# Altium schematic sheet KillSwitch_MotorOut.SchDoc
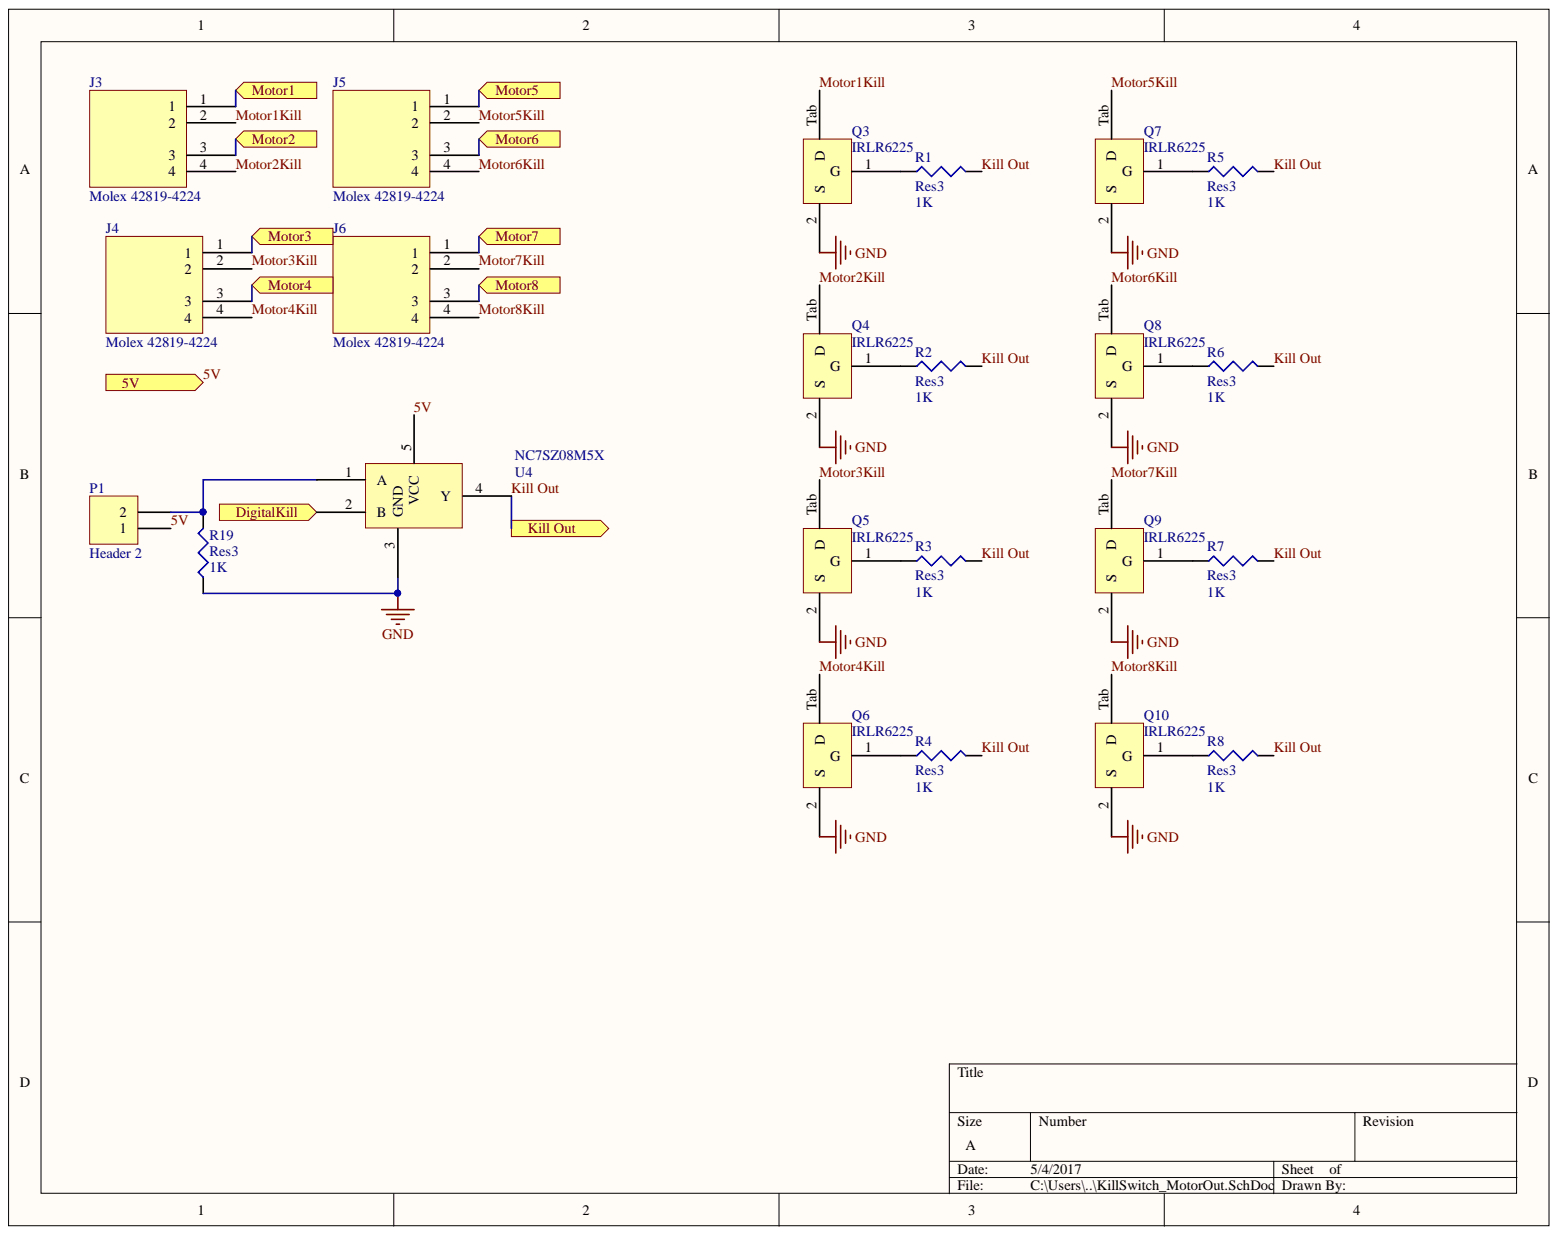
[diagram p0: KillSwitch_MotorOut.SchDoc]

## Parts
J3  Molex 42819-4224  [MOLEX_42819-4224]
J4  Molex 42819-4224  [MOLEX_42819-4224]
J5  Molex 42819-4224  [MOLEX_42819-4224]
J6  Molex 42819-4224  [MOLEX_42819-4224]
NC7SZ08M5X  U4  [NC7SZ08M5X]
P1  Header 2  [HDR1X2]
Q3  IRLR6225  [IRLR6225]
Q4  IRLR6225  [IRLR6225]
Q5  IRLR6225  [IRLR6225]
Q6  IRLR6225  [IRLR6225]
Q7  IRLR6225  [IRLR6225]
Q8  IRLR6225  [IRLR6225]
Q9  IRLR6225  [IRLR6225]
Q10  IRLR6225  [IRLR6225]
R1  Res3  value 1K  [J1-0603]
R2  Res3  value 1K  [J1-0603]
R3  Res3  value 1K  [J1-0603]
R4  Res3  value 1K  [J1-0603]
R5  Res3  value 1K  [J1-0603]
R6  Res3  value 1K  [J1-0603]
R7  Res3  value 1K  [J1-0603]
R8  Res3  value 1K  [J1-0603]
R19  Res3  value 1K  [J1-0603]

## Ports
5V, DIGITALKILL, KILL OUT, MOTOR1, MOTOR2, MOTOR3, MOTOR4, MOTOR5, MOTOR6, MOTOR7, MOTOR8

## Nets
GND: NC7SZ08M5X.3(GND) Q3.2 Q4.2 Q5.2 Q6.2 Q7.2 Q8.2 Q9.2 Q10.2 R19.1
5V: NC7SZ08M5X.5(VCC) P1.1 ; port 5V
KILL OUT: NC7SZ08M5X.4(Y) R1.2 R2.2 R3.2 R4.2 R5.2 R6.2 R7.2 R8.2 ; port KILL OUT
MOTOR1KILL: J3.2 Q3.Tab
MOTOR2KILL: J3.4 Q4.Tab
MOTOR3KILL: J4.2 Q5.Tab
MOTOR4KILL: J4.4 Q6.Tab
MOTOR5KILL: J5.2 Q7.Tab
MOTOR6KILL: J5.4 Q8.Tab
MOTOR7KILL: J6.2 Q9.Tab
MOTOR8KILL: J6.4 Q10.Tab
NetJ3_1: J3.1 ; port MOTOR1
NetJ3_3: J3.3 ; port MOTOR2
NetJ4_1: J4.1 ; port MOTOR3
NetJ4_3: J4.3 ; port MOTOR4
NetJ5_1: J5.1 ; port MOTOR5
NetJ5_3: J5.3 ; port MOTOR6
NetJ6_1: J6.1 ; port MOTOR7
NetJ6_3: J6.3 ; port MOTOR8
NetNC7SZ08M5X_1: NC7SZ08M5X.1(A) P1.2 R19.2
NetNC7SZ08M5X_2: NC7SZ08M5X.2(B) ; port DIGITALKILL
NetQ3_1: Q3.1 R1.1
NetQ4_1: Q4.1 R2.1
NetQ5_1: Q5.1 R3.1
NetQ6_1: Q6.1 R4.1
NetQ7_1: Q7.1 R5.1
NetQ8_1: Q8.1 R6.1
NetQ9_1: Q9.1 R7.1
NetQ10_1: Q10.1 R8.1

## Library data of the parts
J3-J6 = Molex_42819-4224: Component Kind: Standard; Library Name: Molex_42819-4224.SchLib
NC7SZ08M5X = NC7SZ08M5X: Component Kind: Standard; Library Name: NC7SZ08M5X.SchLib
P1 = Header 2: Header, 2-Pin; Component Kind: Standard; LatestRevisionDate: 17-Jul-2002; LatestRevisionNote: Re-released for DXP Platform.; Library Name: Miscellaneous Connectors.IntLib; Signal Integrity: Connector; Publisher: Altium Limited
R1-R8 = Res3: Resistor; Value: 1K; Component Kind: Standard; LatestRevisionDate: 29-May-2009; LatestRevisionNote: IPC-7351 Footprint Added.; Library Name: Miscellaneous Devices.IntLib; PackageDescription: Chip Resistor; PackageReference: J1-0603; Published: 8-Jun-2000; Signal Integrity: Res; Simulation: RESISTOR; Publisher: Altium Limited
R19 = Res3: Resistor; Component Kind: Standard; LatestRevisionDate: 29-May-2009; LatestRevisionNote: IPC-7351 Footprint Added.; Library Name: Miscellaneous Devices.IntLib; PackageDescription: Chip Resistor; PackageReference: J1-0603; Published: 8-Jun-2000; Signal Integrity: Res; Simulation: RESISTOR; Publisher: Altium Limited
Q3-Q10 = IRLR6225: Component Kind: Standard; Library Name: IRLR6225.SchLib
